# Altium schematic sheet IDE-adapter.SchDoc
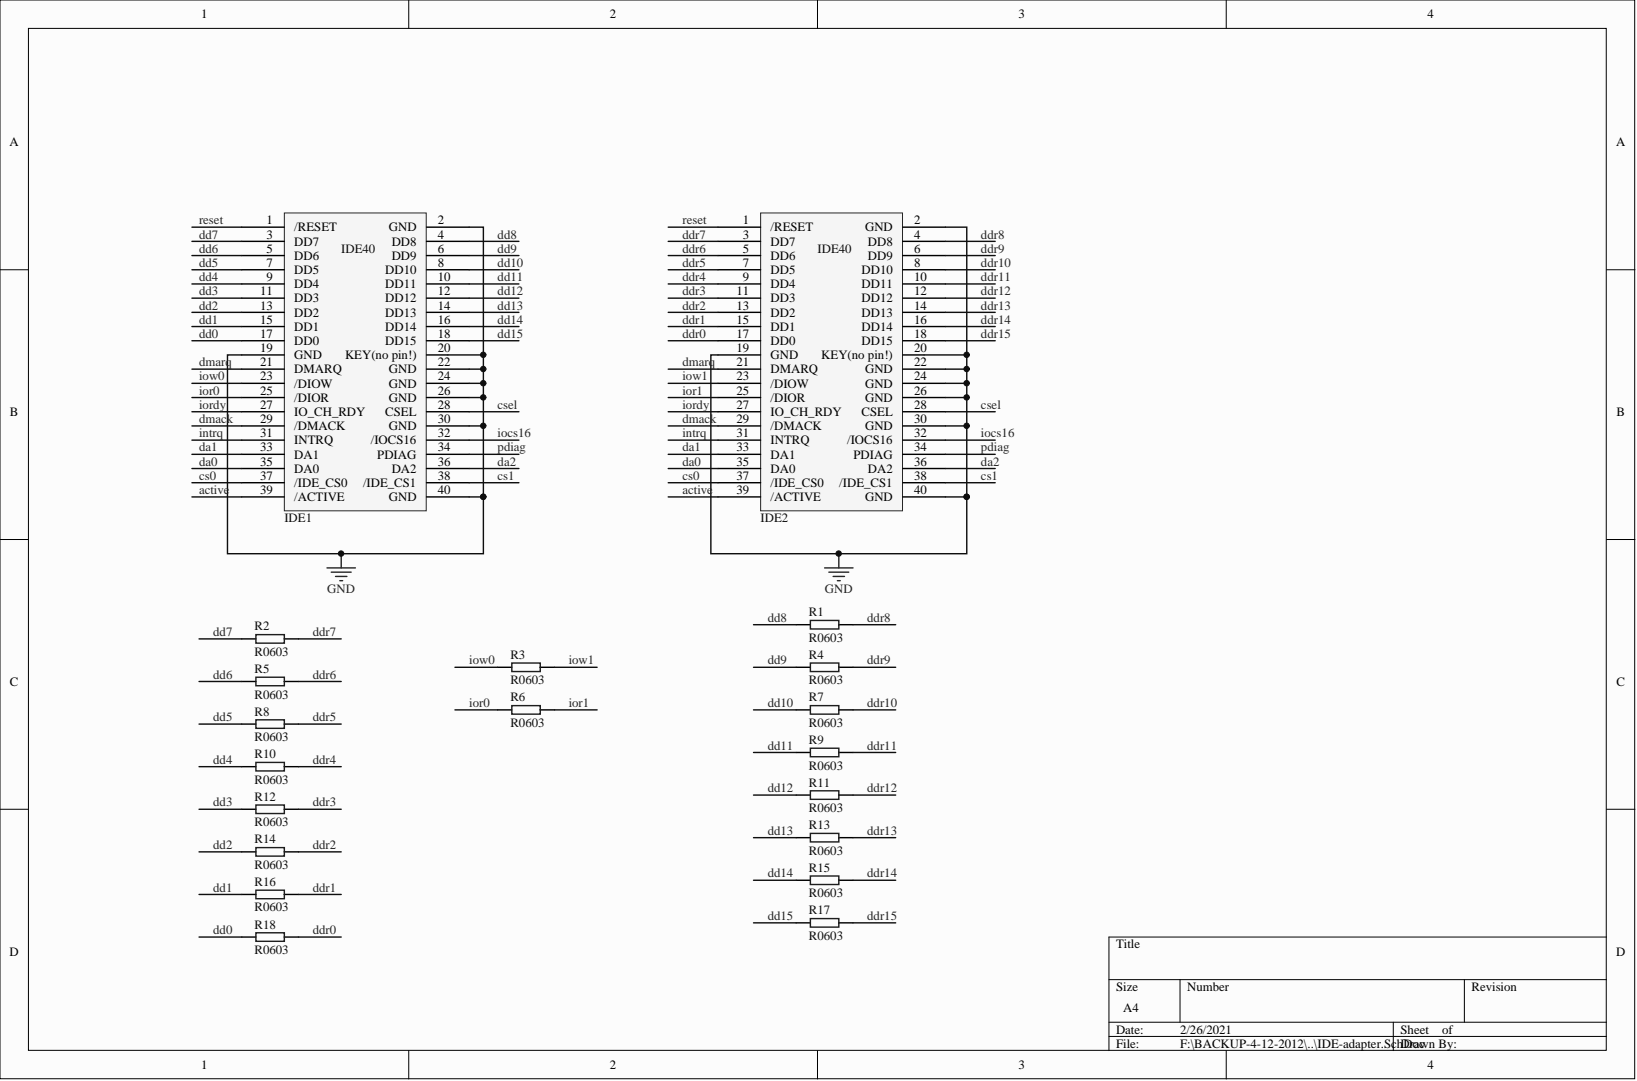
[diagram p0: IDE-adapter.SchDoc]

## Parts
IDE1  IDE40_con  [IDE40_con]  (value not drawn)
IDE2  IDE40_con  [IDE40_con]  (value not drawn)
R1  value R0603  [RESC1609N]  (hidden comment: RES)
R2  value R0603  [RESC1609N]  (hidden comment: RES)
R3  value R0603  [RESC1609N]  (hidden comment: RES)
R4  value R0603  [RESC1609N]  (hidden comment: RES)
R5  value R0603  [RESC1609N]  (hidden comment: RES)
R6  value R0603  [RESC1609N]  (hidden comment: RES)
R7  value R0603  [RESC1609N]  (hidden comment: RES)
R8  value R0603  [RESC1609N]  (hidden comment: RES)
R9  value R0603  [RESC1609N]  (hidden comment: RES)
R10  value R0603  [RESC1609N]  (hidden comment: RES)
R11  value R0603  [RESC1609N]  (hidden comment: RES)
R12  value R0603  [RESC1609N]  (hidden comment: RES)
R13  value R0603  [RESC1609N]  (hidden comment: RES)
R14  value R0603  [RESC1609N]  (hidden comment: RES)
R15  value R0603  [RESC1609N]  (hidden comment: RES)
R16  value R0603  [RESC1609N]  (hidden comment: RES)
R17  value R0603  [RESC1609N]  (hidden comment: RES)
R18  value R0603  [RESC1609N]  (hidden comment: RES)

## Nets
GND: IDE1.2(GND) IDE1.19(GND) IDE1.20(KEY(no_pin!)) IDE1.22(GND) IDE1.24(GND) IDE1.26(GND) IDE1.30(GND) IDE1.40(GND) IDE2.2(GND) IDE2.19(GND) IDE2.20(KEY(no_pin!)) IDE2.22(GND) IDE2.24(GND) IDE2.26(GND) IDE2.30(GND) IDE2.40(GND)
ACTIVE: IDE1.39(/ACTIVE) IDE2.39(/ACTIVE)
CS0: IDE1.37(/IDE_CS0) IDE2.37(/IDE_CS0)
CS1: IDE1.38(/IDE_CS1) IDE2.38(/IDE_CS1)
CSEL: IDE1.28(CSEL) IDE2.28(CSEL)
DA0: IDE1.35(DA0) IDE2.35(DA0)
DA1: IDE1.33(DA1) IDE2.33(DA1)
DA2: IDE1.36(DA2) IDE2.36(DA2)
DD0: IDE1.17(DD0) R18.1
DD1: IDE1.15(DD1) R16.1
DD2: IDE1.13(DD2) R14.1
DD3: IDE1.11(DD3) R12.1
DD4: IDE1.9(DD4) R10.1
DD5: IDE1.7(DD5) R8.1
DD6: IDE1.5(DD6) R5.1
DD7: IDE1.3(DD7) R2.1
DD8: IDE1.4(DD8) R1.1
DD9: IDE1.6(DD9) R4.1
DD10: IDE1.8(DD10) R7.1
DD11: IDE1.10(DD11) R9.1
DD12: IDE1.12(DD12) R11.1
DD13: IDE1.14(DD13) R13.1
DD14: IDE1.16(DD14) R15.1
DD15: IDE1.18(DD15) R17.1
DDR0: IDE2.17(DD0) R18.2
DDR1: IDE2.15(DD1) R16.2
DDR2: IDE2.13(DD2) R14.2
DDR3: IDE2.11(DD3) R12.2
DDR4: IDE2.9(DD4) R10.2
DDR5: IDE2.7(DD5) R8.2
DDR6: IDE2.5(DD6) R5.2
DDR7: IDE2.3(DD7) R2.2
DDR8: IDE2.4(DD8) R1.2
DDR9: IDE2.6(DD9) R4.2
DDR10: IDE2.8(DD10) R7.2
DDR11: IDE2.10(DD11) R9.2
DDR12: IDE2.12(DD12) R11.2
DDR13: IDE2.14(DD13) R13.2
DDR14: IDE2.16(DD14) R15.2
DDR15: IDE2.18(DD15) R17.2
DMACK: IDE1.29(/DMACK) IDE2.29(/DMACK)
DMARQ: IDE1.21(DMARQ) IDE2.21(DMARQ)
INTRQ: IDE1.31(INTRQ) IDE2.31(INTRQ)
IOCS16: IDE1.32(/IOCS16) IDE2.32(/IOCS16)
IOR0: IDE1.25(/DIOR) R6.1
IOR1: IDE2.25(/DIOR) R6.2
IORDY: IDE1.27(IO_CH_RDY) IDE2.27(IO_CH_RDY)
IOW0: IDE1.23(/DIOW) R3.1
IOW1: IDE2.23(/DIOW) R3.2
PDIAG: IDE1.34(PDIAG) IDE2.34(PDIAG)
RESET: IDE1.1(/RESET) IDE2.1(/RESET)

## Library data of the parts
IDE1 IDE2 = IDE40_con: Database Table Name: CONN; ID: 28; Component: IDE40_con; Component Kind: Standard; Description: IDE40_con; Value: IDE40_con; Library Name: trioflex.DbLib
R1-R18 = R0603: Database Table Name: R; ID: 9; Component: R0603; Component Kind: Standard; Library Name: trioflex.DbLib
